# Altium schematic sheet 000_Power.SchDoc
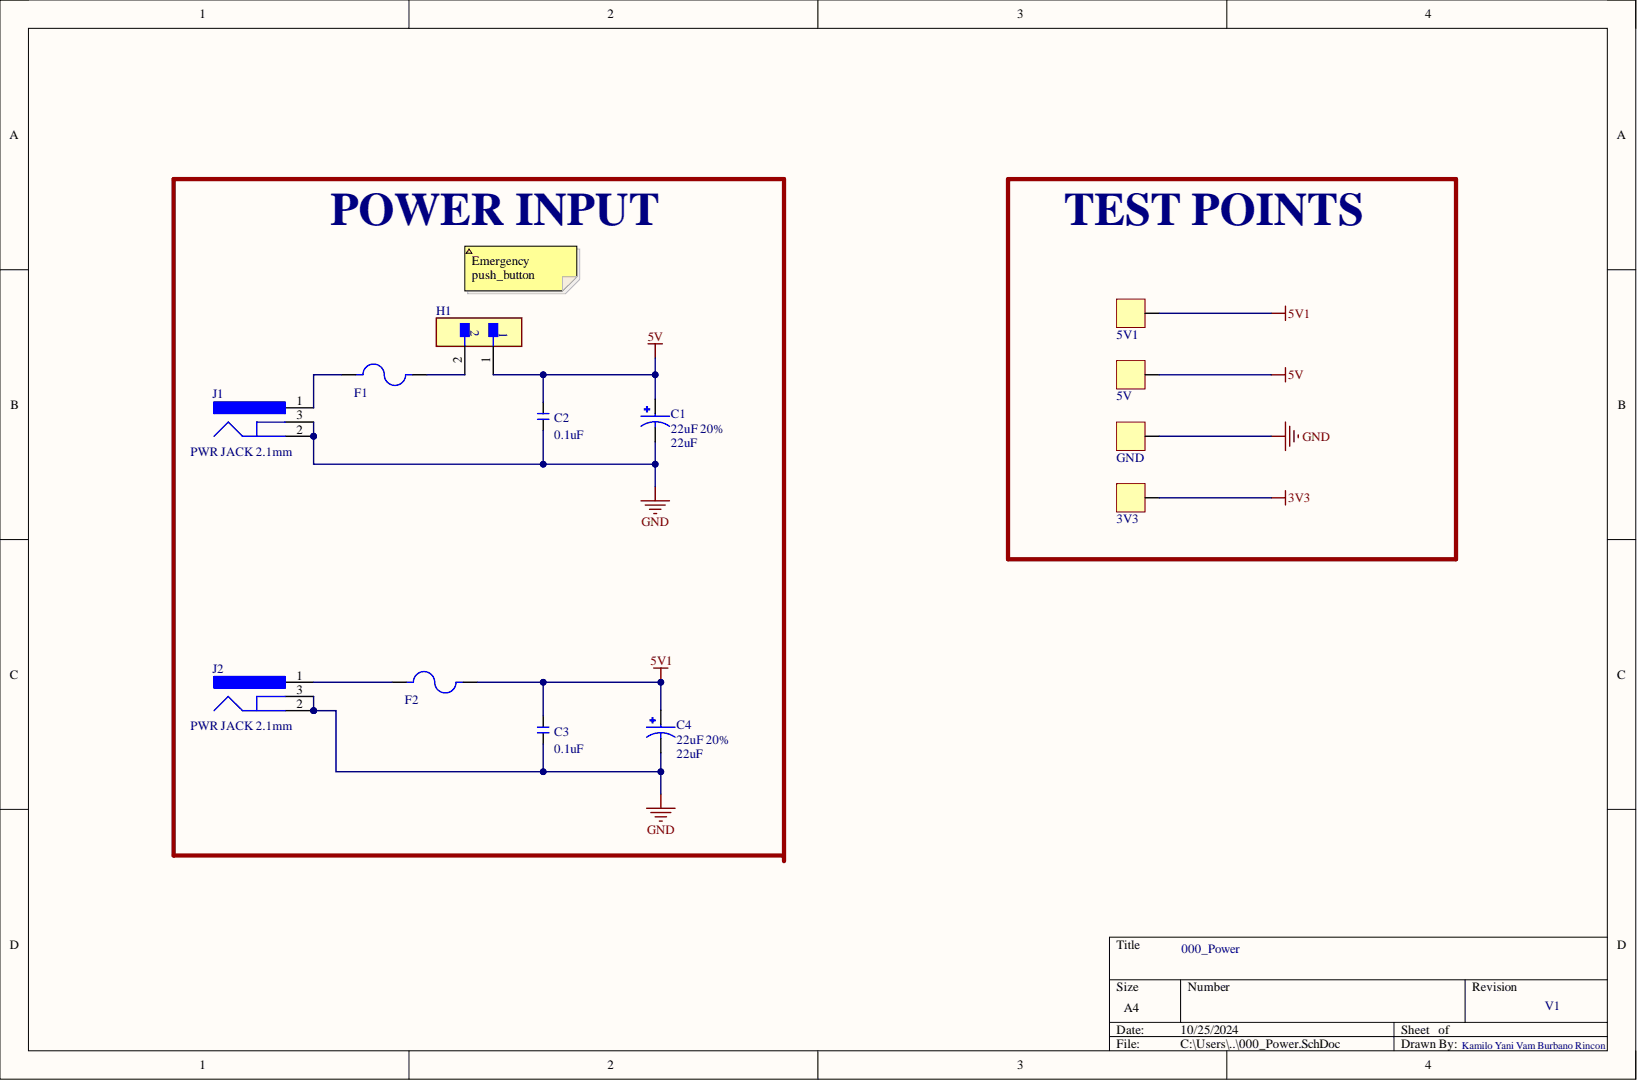
[diagram p0: 000_Power.SchDoc]

## Parts
3V3  TP_SMT_30mil  [TP_SMT_30mil]  (value not drawn)
5V  TP_SMT_30mil  [TP_SMT_30mil]  (value not drawn)
5V1  TP_SMT_30mil  [TP_SMT_30mil]  (value not drawn)
C1  22uF 20%  value 22uF  [CAP_THT_RADIAL_2]
C2  value 0.1uF  [CAP_THT_3.0mm]  (hidden comment: 0.1uF 20%)
C3  value 0.1uF  [CAP_THT_3.0mm]  (hidden comment: 0.1uF 20%)
C4  22uF 20%  value 22uF  [CAP_THT_RADIAL_2]
F1  F_1A_250V  [F_5mm*20mm]  (value not drawn)
F2  F_1A_250V  [F_5mm*20mm]  (value not drawn)
GND  TP_SMT_30mil  [TP_SMT_30mil]  (value not drawn)
H1  H_2x1_THT_2.54mm_Header  [H_2x1_THT_2.54mm]  (value not drawn)
J1  PWR JACK 2.1mm  [CON_TH_2.1mm_PWR_JACK]
J2  PWR JACK 2.1mm  [CON_TH_2.1mm_PWR_JACK]

## Nets
3V3: 3V3.1
5V: 5V.1 C1.P C2.2 H1.1
5V1: 5V1.1 C3.2 C4.P F2.1_2
GND: C1.N C2.1 C3.1 C4.N GND.1 J1.2 J1.3 J2.2 J2.3
NetF1_1_1: F1.1_1 J1.1
NetF1_1_2: F1.1_2 H1.2
NetF2_1_1: F2.1_1 J2.1

## Library data of the parts
3V3 5V 5V1 GND = TP_SMT_30mil: Test point 30mil hole size; Component Kind: Standard; ComponentLink1Description: Digi-Key; Last modified date: 20231205; Supplier 1: Digi-key; Last modified by: K-Y.Burbano; Library Name: Library_Kam_ilo.IntLib
C1 C4 = Cap_22uF_16V_THT: 22uF   ±20% 50V Electrolict Capacitor Y5V; Component Kind: Standard; ComponentLink1Description: VISTRONICA; Last modified date: 2024055; Library Name: Library_laser.IntLib; Supplier 1: VISTRONICA; Last modified by: K-Y.Burbano
C2 C3 = Cap_0.1uF_50V_THT: 0.1uF   ±20% 50V Ceramic Capacitor Y5V; Component Kind: Standard; ComponentLink1Description: VISTRONICA; ComponentLink1URL: https://www.vistronica.com/componentes-pasivos/capacitores/condensador-ceramico-104-01uf-100nf--detail.html; Last modified date: 2024055; Supplier 1: VISTRONICA; Last modified by: K-Y.Burbano; Library Name: Library_Kam_ilo.IntLib
F1 F2 = F_1A_250V: FUSE BOARD MNT 1A 32VDC; Component Kind: Standard; ComponentLink1Description: Digi-Key; ComponentLink1URL: https://www.vistronica.com/kit-porta-fusible-5x20-para-pcb-detail.html; Last modified date: 2024057; Supplier 1: VISTRONICA; Last modified by: K-Y.Burbano; Library Name: Library_Kam_ilo.IntLib
H1 = H_2x1_THT_2.54mm_Header: CONN HEADER THT 2POS 2.54MM; Component Kind: Standard; ComponentLink1Description: Digi-Key; ComponentLink1URL: https://www.digikey.com/en/products/detail/samtec-inc/TSM-102-01-L-SV-P-TR/9593647; Last modified date: 2024058; Last modified by: K-Y.Burbano; Library Name: Library_Kam_ilo.IntLib
J1 J2 = Con_TH_2.1mm_PWR_JACK: CONN PWR JACK 2X5.5MM SOLDER; Manufacturer: CUI Devices; Manufacturer Part Number: PJ-002A; Component Kind: Standard; ComponentLink1Description: Digi-Key; ComponentLink1URL: https://www.digikey.com/en/products/detail/cui-inc/PJ-002A/96962; Last modified date: 20231204; Supplier 1: Digi-key; Supplier Part Number 1: CP-002A-ND; Last modified by: K-Y.Burbano; Library Name: Library_Kam_ilo.IntLib
